# Altium schematic sheet Power_Supply_Current_Transformers.SchDoc(PS_CT2)
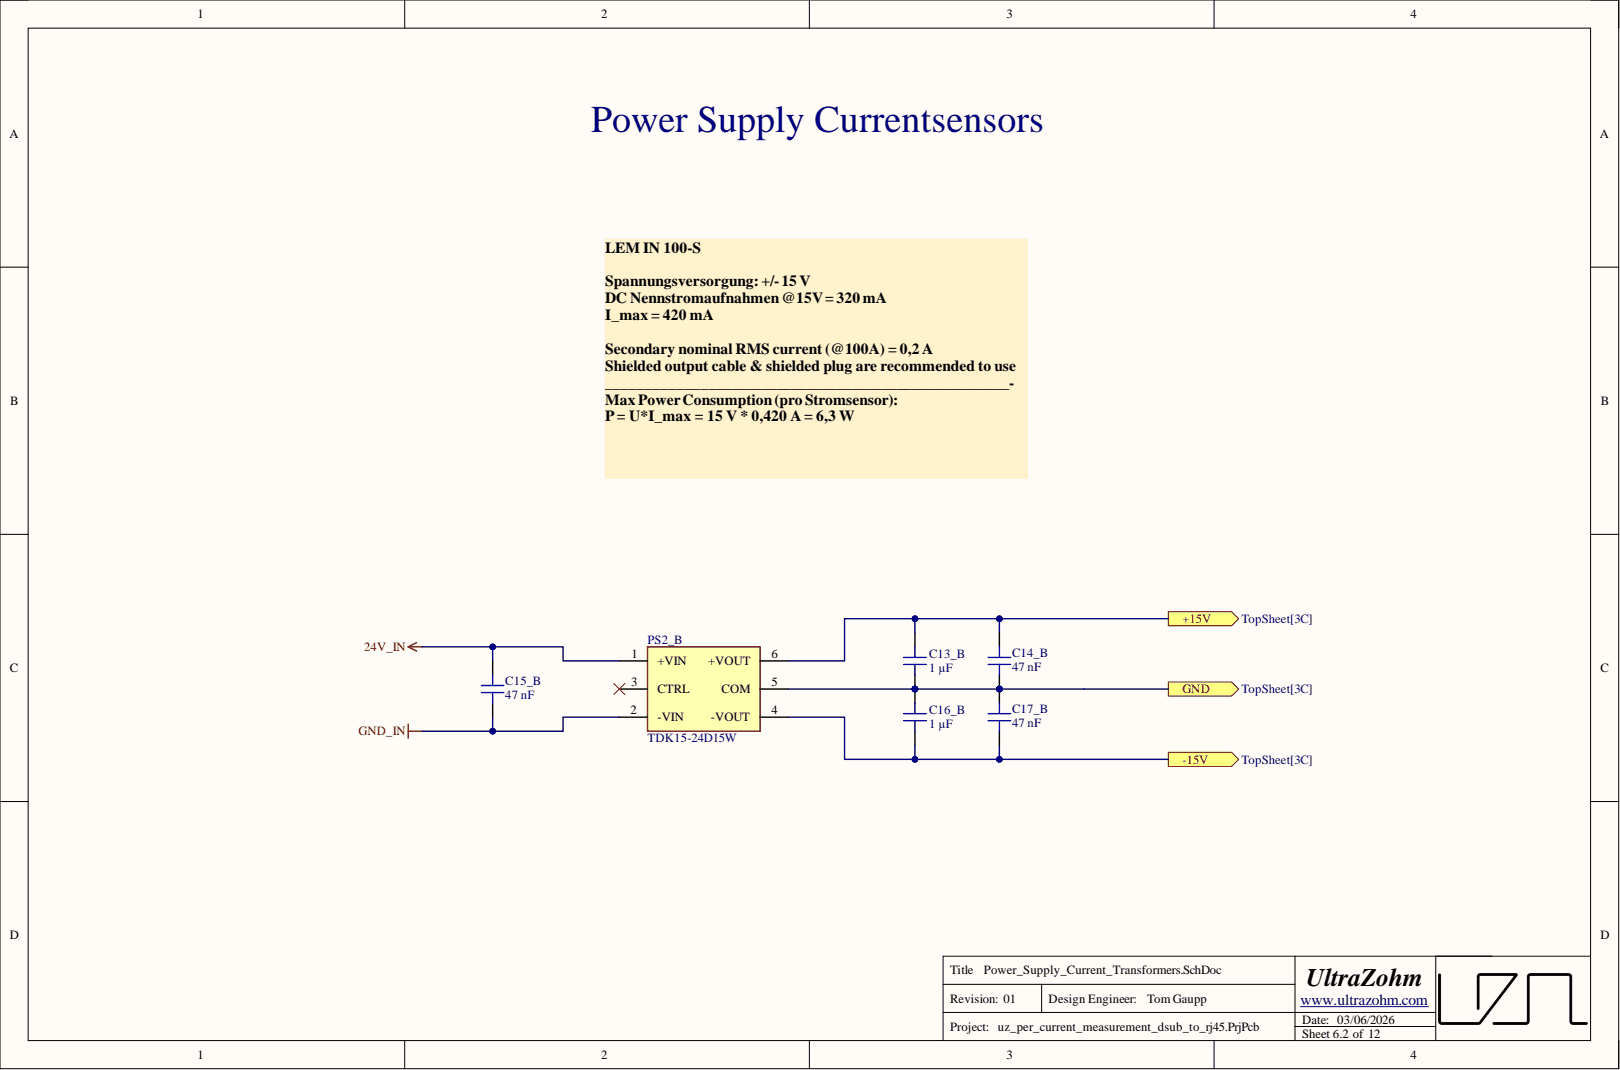
[diagram p0: Power_Supply_Current_Transformers.SchDoc]

## Parts
C13_B  1 µF  [C_0603]
C14_B  47 nF  [C_0603]
C15_B  47 nF  [C_0603]
C16_B  1 µF  [C_0603]
C17_B  47 nF  [C_0603]
PS2_B  TDK15-24D15W  [TDK15-24D15W]

## Ports
+15V_B, -15V_B, GND_B

## Nets
24V_IN: C15_B.2 PS2_B.1(+VIN)
GND_IN: C15_B.1 PS2_B.2(-VIN)
NetC13_B_1: C13_B.1 C14_B.1 C16_B.2 C17_B.2 PS2_B.5(COM)
NetC13_B_2: C13_B.2 C14_B.2 PS2_B.6(+VOUT)
NetC16_B_1: C16_B.1 C17_B.1 PS2_B.4(-VOUT)
NetPS2_B_3: PS2_B.3(CTRL)

## Library data of the parts
C13_B C16_B = CL10A105KB8NNNC: 50V 1uF X5R ±10% 0603  Multilayer Ceramic Capacitors MLCC - SMD/SMT ROHS; Manufacturer: Samsung Electro-Mechanics; Created from: MGremer; Database Table Name: Capacitors - SMD; Voltage Rating: 50 VDC; Component Kind: Standard; Component Type: Capacitor 0603; ComponentLink1Description: Datasheet; ComponentLink1URL: https://datasheet.lcsc.com/lcsc/1810261812_Samsung-Electro-Mechanics-CL10A105KB8NNNC_C15849.pdf; Created On: 20.12.2020 13:54:13; Device Package: 0603; Last Updated: 28.11.2022 17:23:01; LCSC Part Number: C15849; Library Name: user.DbLib; Live Cycle Status: 1; Manufacturer Part Number: CL10A105KB8NNNC; Mounting Type: SMD; Operating Temperature: -55 - 85°C; PartID: 5; Series: M; Stock number: Labor SMD Container; Supplier 1: LCSC; Supplier Part Number 1: C15849; Tolerance: 10 %; Type: Capacitor; Value: 1 µF
C14_B C15_B C17_B = CL10B473KB8NNNC: Capacitor 0603, 50 VDC, 47 nF, X7R; Manufacturer: Samsung Electro-Mechanics; Created from: DHufnagel; Database Table Name: Capacitors - SMD; Tolerance: 10 %; Voltage Rating: 50 VDC; Component Kind: Standard; Component Type: Capacitor 0603; ComponentLink1Description: Datasheet; ComponentLink1URL: https://www.mouser.de/datasheet/2/585/MLCC-1837944.pdf; Created On: 20/07/2022 09:55:05; Device Package: 0603; Last Updated: 20/07/2022 09:55:05; LCSC Part Number: C1622; Library Name: user.DbLib; Live Cycle Status: 1; Manufacturer Part Number: CL10B473KB8NNNC; Mounting Type: SMD; Operating Temperature: -55 - 125°C; PartID: 97; Series: X7R; Stock number: not stored; Supplier 1: Mouser; Supplier 2: LCSC; Supplier Part Number 1: 187-CL10B473KB8NNNC; Supplier Part Number 2: C1622; Type: Capacitor; Value: 47 nF
PS2_B = TDK15-24D15W: Isolated Module DC DC Converter Buck 2 Output ±15V 500mA 9V~36V Input; Manufacturer: TDPOWER; Created from: TGaupp; Database Table Name: Power Supply - DC DC Switching Regulators; Component Kind: Standard; Component Type: DC-DC Converters; ComponentLink1Description: Datasheet; ComponentLink1URL: https://www.lcsc.com/datasheet/C783530.pdf?spm=wm.sxq.inf.ggs___wm.fly.bg.0.xh&lcsc_vid=RlUMVwJUE1RdV1AHElFcBVIFQlFcAQcFQlFaAgcCR1gxVlNRTlNWVFZeR1NeXzsOAxUeFF5JWBYZEEoKFBINSQcJGk4NBhADEA4cHktXRlhXSQwSGg0%3D; Created On: 20/05/2026 14:56:35; Device Package: 25.4 mm x 25.4 mm x 11mm; Last Updated: 20/05/2026 15:16:51; LCSC Part Number: C783530; Library Name: user.DbLib; Live Cycle Status: 1; Manufacturer Part Number: TDK15-24D15W; Mounting Type: THT; Operating Temperature: -40℃~+85℃; PartID: 26; Stock number: not stored; Supplier 1: LCSC; Supplier Part Number 1: C783530; Type: DC-DC Converters
